# Altium schematic sheet Top.SchDoc
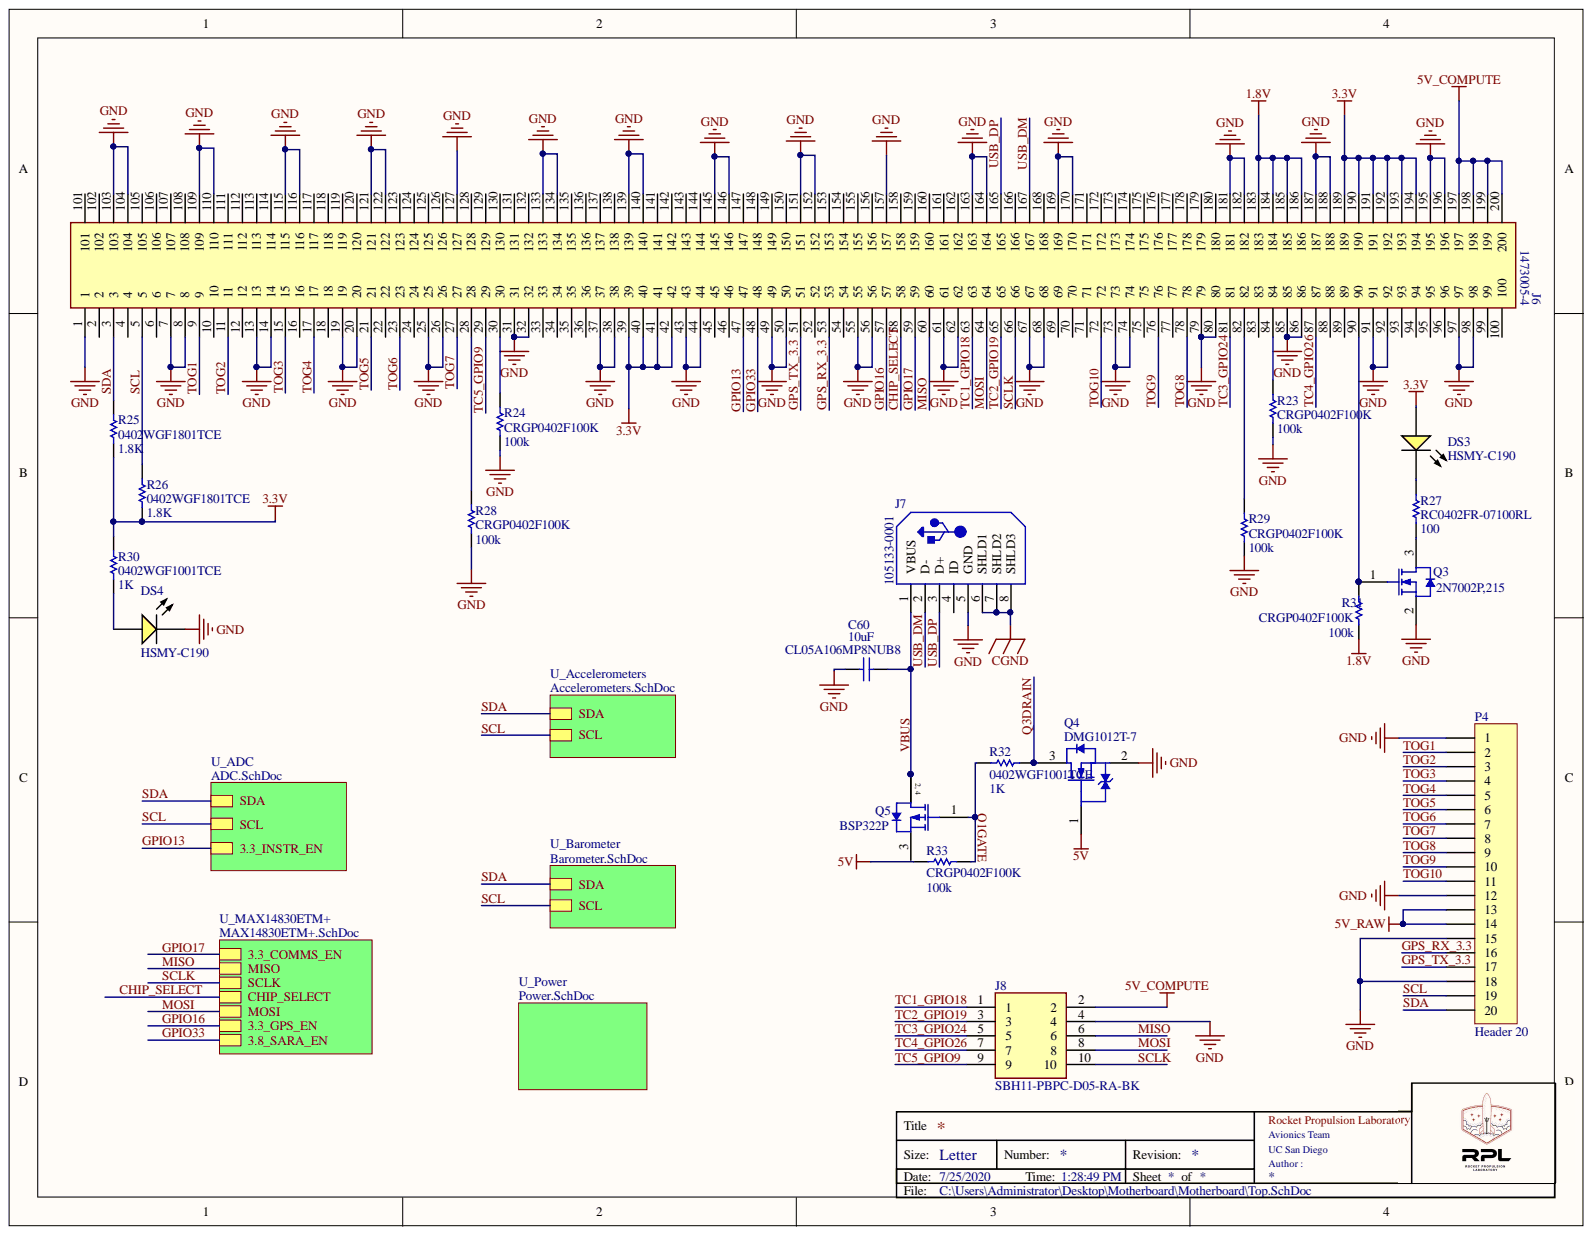
[diagram p0: Top.SchDoc]

## Parts
C60  CL05A106MP8NUB8  value 10uF  [0402]
DS3  HSMY-C190  [AVAG-HSMX-C190_V]
DS4  HSMY-C190  [AVAG-HSMX-C190_V]
J6  1473005-4  [1473005-4_1]
J7  105133-0001  [MOLX-105133-0001_V]
J8  SBH11-PBPC-D05-RA-BK  [SHDRRA10W64P254_2X5_1270X910X880P]
P4  Header 20  [HDR1X20]
Q3  2N7002P,215  [NXP-SOT23_L]
Q4  DMG1012T-7  [DIOD-SOT523-3_V]
Q5  BSP322P  [INF-PG-SOT223_SC-73_M]
R23  CRGP0402F100K  value 100k  [0402]
R24  CRGP0402F100K  value 100k  [0402]
R25  0402WGF1801TCE  value 1.8K  [0402]
R26  0402WGF1801TCE  value 1.8K  [0402]
R27  RC0402FR-07100RL  [RESC1005X40X25ML05T10]
R28  CRGP0402F100K  value 100k  [0402]
R29  CRGP0402F100K  value 100k  [0402]
R30  0402WGF1001TCE  value 1K  [0402]
R31  CRGP0402F100K  value 100k  [0402]
R32  0402WGF1001TCE  value 1K  [0402]
R33  CRGP0402F100K  value 100k  [0402]

## Nets
1.8V: J6.183 J6.184 J6.185 J6.186 R31.2
3.3V: DS3.1 J6.39 J6.40 J6.41 J6.42 J6.189 J6.190 J6.191 J6.192 J6.193 J6.194 R25.1 R26.1 R30.1
5V: Q4.1 R33.2
5V_COMPUTE: J6.197 J6.198 J6.199 J6.200 J8.2
5V_RAW: P4.13 P4.14
CGND: J7.6(SHLD1) J7.7(SHLD2) J7.8(SHLD3)
GND: C60.2 DS4.2 J6.1 J6.7 J6.8 J6.13 J6.14 J6.19 J6.20 J6.25 J6.26 J6.31 J6.32 J6.37 J6.38 J6.43 J6.44 J6.49 J6.50 J6.55 J6.56 J6.61 J6.62 J6.67 J6.68 J6.73 J6.74 J6.79 J6.80 J6.85 J6.86 J6.91 J6.92 J6.97 J6.98 J6.103 J6.104 J6.109 J6.110 J6.115 J6.116 J6.121 J6.122 J6.127 J6.133 J6.134 J6.139 J6.140 J6.145 J6.146 J6.151 J6.152 J6.157 J6.163 J6.164 J6.169 J6.170 J6.181 J6.182 J6.187 J6.188 J6.195 J6.196 J7.5(GND) J8.4 P4.1 P4.12 P4.15 P4.18 Q3.2 Q4.2 R23.2 R24.2 R28.2 R29.2
CHIP_SELECT: J6.58
GPIO13: J6.47
GPIO16: J6.57
GPIO17: J6.59
GPIO33: J6.48
GPS_RX_3.3: J6.53 P4.16
GPS_TX_3.3: J6.51 P4.17
MISO: J6.60 J8.6
MOSI: J6.64 J8.8
Q1GATE: Q5.1 R32.2 R33.1
Q3DRAIN: Q4.3 R32.1
SCL: J6.5 P4.19 R26.2
SCLK: J6.66 J8.10
SDA: J6.3 P4.20 R25.2
TC1_GPIO18: J6.63 J8.1
TC2_GPIO19: J6.65 J8.3
TC3_GPIO24: J6.81 J8.5
TC4_GPIO26: J6.87 J8.7
TC5_GPIO9: J6.29 J8.9
TOG1: J6.9 P4.2
TOG2: J6.11 P4.3
TOG3: J6.15 P4.4
TOG4: J6.17 P4.5
TOG5: J6.21 P4.6
TOG6: J6.23 P4.7
TOG7: J6.27 P4.8
TOG8: J6.78 P4.9
TOG9: J6.76 P4.10
TOG10: J6.72 P4.11
USB_DM: J6.167 J7.2(D-)
USB_DP: J6.165 J7.3(D+)
NetC60_1: C60.1 J7.1(VBUS) Q5.2 Q5.4
NetDS3_2: DS3.2 R27.2
NetDS4_1: DS4.1 R30.2
NetJ6_2: J6.2
NetJ6_4: J6.4
NetJ6_6: J6.6
NetJ6_10: J6.10
NetJ6_12: J6.12
NetJ6_16: J6.16
NetJ6_18: J6.18
NetJ6_22: J6.22
NetJ6_24: J6.24
NetJ6_28: J6.28 R28.1
NetJ6_30: J6.30 R24.1
NetJ6_33: J6.33
NetJ6_34: J6.34
NetJ6_35: J6.35
NetJ6_36: J6.36
NetJ6_45: J6.45
NetJ6_46: J6.46
NetJ6_52: J6.52
NetJ6_54: J6.54
NetJ6_69: J6.69
NetJ6_70: J6.70
NetJ6_71: J6.71
NetJ6_75: J6.75
NetJ6_77: J6.77
NetJ6_82: J6.82 R29.1
NetJ6_83: J6.83
NetJ6_84: J6.84 R23.1
NetJ6_88: J6.88
NetJ6_89: J6.89
NetJ6_90: J6.90 Q3.1 R31.1
NetJ6_93: J6.93
NetJ6_94: J6.94
NetJ6_95: J6.95
NetJ6_96: J6.96
NetJ6_99: J6.99
NetJ6_100: J6.100
NetJ6_101: J6.101
NetJ6_102: J6.102
NetJ6_105: J6.105
NetJ6_106: J6.106
NetJ6_107: J6.107
NetJ6_108: J6.108
NetJ6_111: J6.111
NetJ6_112: J6.112
NetJ6_113: J6.113
NetJ6_114: J6.114
NetJ6_117: J6.117
NetJ6_118: J6.118
NetJ6_119: J6.119
NetJ6_120: J6.120
NetJ6_123: J6.123
NetJ6_124: J6.124
NetJ6_125: J6.125
NetJ6_126: J6.126
NetJ6_128: J6.128
NetJ6_129: J6.129
NetJ6_130: J6.130
NetJ6_131: J6.131
NetJ6_132: J6.132
NetJ6_135: J6.135
NetJ6_136: J6.136
NetJ6_137: J6.137
NetJ6_138: J6.138
NetJ6_141: J6.141
NetJ6_142: J6.142
NetJ6_143: J6.143
NetJ6_144: J6.144
NetJ6_147: J6.147
NetJ6_148: J6.148
NetJ6_149: J6.149
NetJ6_150: J6.150
NetJ6_153: J6.153
NetJ6_154: J6.154
NetJ6_155: J6.155
NetJ6_156: J6.156
NetJ6_158: J6.158
NetJ6_159: J6.159
NetJ6_160: J6.160
NetJ6_161: J6.161
NetJ6_162: J6.162
NetJ6_166: J6.166
NetJ6_168: J6.168
NetJ6_171: J6.171
NetJ6_172: J6.172
NetJ6_173: J6.173
NetJ6_174: J6.174
NetJ6_175: J6.175
NetJ6_176: J6.176
NetJ6_177: J6.177
NetJ6_178: J6.178
NetJ6_179: J6.179
NetJ6_180: J6.180
NetJ7_4: J7.4(ID)
NetQ3_3: Q3.3 R27.1
NetQ5_3: Q5.3
VBUS:

## Library data of the parts
C60 = Cap: Capacitor; Component Kind: Standard; LatestRevisionDate: 17-Jul-2002; LatestRevisionNote: Re-released for DXP Platform.; Library Name: Miscellaneous Devices.IntLib; PackageDescription: Radial Cap, Thru-Hole; 2 Leads; 0.3 in Pin Spacing; PackageReference: RAD-0.3; Published: 8-Jun-2000; Signal Integrity: Cap; Simulation: CAP; Publisher: Altium Limited
DS3 DS4 = CMP-1744-00003-1: LED Uni-Color Yellow, 65 mW, 20 mA, -40 to 85 degC, 2-Pin SMD, RoHS, Tape and Reel; Case\Package: 0603; Power Rating: 100 mW; Resistance: 390 Ohm; Tolerance: 1%; Voltage Rating: 50 V; Case Code (Imperial): 0603; Case Code (Metric): 1608; Component Kind: Standard; ComponentLink1Description: Manufacturer URL; ComponentLink1URL: http://www.avagotech.com/; ComponentLink2Description: Datasheet; ComponentLink2URL: http://docs.avagotech.com/docs/AV02-0551EN; ComponentLink3Description: Package Specification; ComponentLink3URL: http://www.fairchildsemi.com/dwg/MA/MA03D.pdf; DatasheetVersion: Mar-12; Height: 0.45 mm; Length: 1.6 mm; Library Name: Altium Content Vault; Max Operating Temperature: 155 degC; Min Operating Temperature: -55 degC; Mount: Surface Mount; PackageDescription: 2-Pin Surface Mount Device, Body 1.6 x 0.8 mm, Height 0.9 mm; Package Quantity: 5000; PackageReference: HSMX-C190; Packaging: Tape and Reel; Pins: 2; REACH SVHC: No SVHC; RoHSCompliant: true; Voltage Rating (DC): 50 V; Width: 0.8 mm
J6 = 1473005-4: Connector; Manufacturer_Name: TE Connectivity; Arrow Part Number: 1473005-4; Arrow Price/Stock: https://www.arrow.com/en/products/1473005-4/te-connectivity; Component Kind: Standard; Datasheet Link: http://docs-emea.rs-online.com/webdocs/06cc/0900766b806ccc0f.pdf; Manufacturer_Part_Number: 1473005-4; Mouser Part Number: 571-1473005-4; Mouser Price/Stock: https://www.mouser.co.uk/ProductDetail/TE-Connectivity/1473005-4?qs=VZ9FDhhp7Sl6t6qwUOr3aA%3D%3D
J7 = CMP-2000-05829-1: Micro-USB B Receptacle, Vertical, -30 to 85 degC, 5-Pin SMD, RoHS, Tape and Reel; Brand: Molex; Connector Type: MicroUSBTypeBReceptacle; Current Rating: 1.8A; Number of Ports: 1; Package Version: Rev. C, 11/2015; Voltage - Max: 30V; Voltage Rating: 30VAC; Color: Black; Component Kind: Standard; Contact Material: CopperAlloy; Contact Plating: Gold; Datasheet URL: http://www.molex.com/webdocs/datasheets/pdf/en-us/1051330001_IO_CONNECTORS.pdf; Datasheet Version: 03/2016; Factory Pack Quantity: 6000; Flammability Rating: UL94V-0; Gender: Receptacle; Library Name: Altium Content Vault; Manufacturer: Molex; Manufacturer URL: http://www.molex.com/; Mounting Angle: Vertical; Mounting Style: SMD/SMT; Mounting Technology: SurfaceMount; Package Description: 5-Pin Surface Mount Device, Pitch 0.65 mm; Package Reference: 105133-0001; Package URL: http://www.molex.com/pdm_docs/sd/1051330001_sd.pdf; Packaging: Reel; PartNumber: 105133-0001; Product: MicroUSBTypeBConnectors; Product Category: USBConnectors; RoHS: Details; Series: 105133; Standard: USB; Termination Style: SolderTail
J8 = SBH11-PBPC-D05-RA-BK: Connector; Manufacturer_Name: Sullins; Arrow Part Number: SBH11-PBPC-D05-RA-BK; Component Kind: Standard; Datasheet Link: http://sullinscorp.com/catalogs/145_PAGE118_.100_SBH11_SERIES_MALE_BOX_HDR_ST_RA_SMT.pdf; Height: 8.8mm; Manufacturer_Part_Number: SBH11-PBPC-D05-RA-BK
P4 = Header 20: Header, 20-Pin; Component Kind: Standard; LatestRevisionDate: 17-Jul-2002; LatestRevisionNote: Re-released for DXP Platform.; Library Name: Miscellaneous Connectors.IntLib; Signal Integrity: Connector; Publisher: Altium Limited
Q3 = CMP-1259-00082-1: N-Channel Trench MOSFET, 60 V, 0.36 A, -55 to 150 degC, 3-Pin SOT23, Tape and Reel; Manufacturer: NXP Semiconductors; Automotive Qualified: Y; Channel Type: N; ID [max] (A): 0.36; IDM [max] (A): 1.2; Packing: Tape & Reel; VDS [max] (V): 60; VGSth [typ] (V): 1.75; Code_JEDEC: TO-236AB; Component Kind: Standard; ComponentLink1Description: Manufacturer URL; ComponentLink1URL: http://www.nxp.com/; ComponentLink2Description: Datasheet; ComponentLink2URL: http://www.nxp.com/documents/data_sheet/2N7002P.pdf; ComponentLink3Description: Package Specification; ComponentLink3URL: http://www.nxp.com/documents/outline_drawing/sot023_po.pdf; DatasheetVersion: Rev. 02; Library Name: Altium Content Vault; Mounting Technology: Surface Mount; PackageDescription: 3-Pin Small Outline Transistor Package, Body 2.9 x 1.3 mm, Pitch 0.95 mm; PackageReference: SOT23; PackageVersion: 06-03-16, 03/2006; PartNumber: 2N7002P,215
Q4 = CMP-2000-07450-1: N-Channel Enhancement Mode MOSFET, 20 V, 630 mA, -55 to 150 degC, 3-Pin SOT23, RoHS, Tape and Reel; Manufacturer: Diodes Inc; Case\/Package: SOT-523; Continuous Drain Current (ID): 630mA; Drain to Source Breakdown Voltage: 20V; Drain to Source Resistance: 300mOhm; Element Configuration: Single; Fall Time: 12.3ns; Gate to Source Voltage (Vgs): 6V; Input Capacitance: 60.67pF; Max Power Dissipation: 280mW; Number of Channels: 1; On-State Resistance: 400MOhm; Rise Time: 7.4ns; Threshold Voltage: 1V; Turn-Off Delay Time: 26.7ns; Turn-On Delay Time: 5.1ns; Component Kind: Standard; Contact Plating: Matte; Datasheet URL: https://www.diodes.com/assets/Datasheets/ds31783.pdf; Datasheet Version: Rev. 3-2, 01/2012; Drain to Source Voltage (Vdss): 20V; Height: 0.8mm; Lead Free: LeadFree; Length: 1.7mm; Library Name: Altium Content Vault; Manufacturer URL: http://www.diodes.com/; Max Operating Temperature: 150degC; Min Operating Temperature: -55degC; Mount: SurfaceMount; Mounting Technology: SurfaceMount; Number of Elements: 1; Number of Pins: 3; Package Description: 3-Pin Small Outline Transistor, Body 1.6 x 0.8 mm, Pitch 0.5 mm; Package Quantity: 3000; Package Reference: SOT523-3; Packaging: TapeandReel; Power Dissipation: 280mW; Radiation Hardening: No; Rds On Max: 400mOhm; REACH SVHC: NoSVHC; RoHSCompliant: true; Weight: 7.1E-05oz; Width: 0.85mm
Q5 = CMP-1099-00018-1: P-Channel SIPMOS Small-Signal-Transistor, -100 V VDS, -1 A ID, -55 to 150 degC, PG-SOT223 (SC-73), Reel, Green; Manufacturer: Infineon; Green: TRUE; ID (max): -1Â A; IDpuls (max): -4Â A; Mode: Enhancement; Polarity: P; Pto t (max): 1.8Â W; QG: 12.4Â nC; RDS (on) (max): 800Â mOhm; RDS (on) (max) (@4.5V): 1,000Â mOhm; Rth: 115Â K/W; VDS (max): -100Â V; VGS(th) (max): -1Â V; VGS(th) (min): -2Â V; Component Kind: Standard; ComponentLink1Description: Manufacturer URL; ComponentLink1URL: http://www.infineon.com/; ComponentLink2Description: Datasheet; ComponentLink2URL: http://www.infineon.com/dgdl/BSP322P_Rev15.pdf?folderId=db3a304314dca38901154a72e3951a65&fileId=db3a30433b47825b013b60a022246d9c; ComponentLink3Description: Package Specification; ComponentLink3URL: http://www.infineon.com/dgdl/SOT223-Package_Overview.pdf?folderId=db3a30431936bc4b0119530470b03c3d&fileId=db3a30431936bc4b0119531d40b93c5c; DatasheetVersion: Rev. 1.05; Halogen-free: TRUE; Library Name: Altium Content Vault; Mounting Technology: Surface Mount; PackageDescription: 4-Pin Small Outline Transistor Package, 6.5 x 3.5 mm Body, 2.3 mm Pitch; PackageReference: PG-SOT223 (SC-73); PackageVersion: Apr-08; Packing: Reel; PartNumber: BSP322P; Technology: SIPMOS
R23-R26 R28-R33 = Res1: Resistor; Component Kind: Standard; LatestRevisionDate: 17-Jul-2002; LatestRevisionNote: Re-released for DXP Platform.; Library Name: Miscellaneous Devices.IntLib; PackageDescription: Axial Device, Thru-Hole; 2 Leads; 0.3 in Pin Spacing; PackageReference: AXIAL-0.3; Published: 8-Jun-2000; Signal Integrity: Res; Simulation: RESISTOR; Publisher: Altium Limited
R27 = CMP-2002-07344-1: Manufacturer: Yageo / Phycomp; spec: 100; Component Kind: Standard; Library Name: Altium Content Vault; Part Number: RC0402FR-07100RL
